# Altium schematic sheet JacdacSingleRgbLed 42.SchDoc
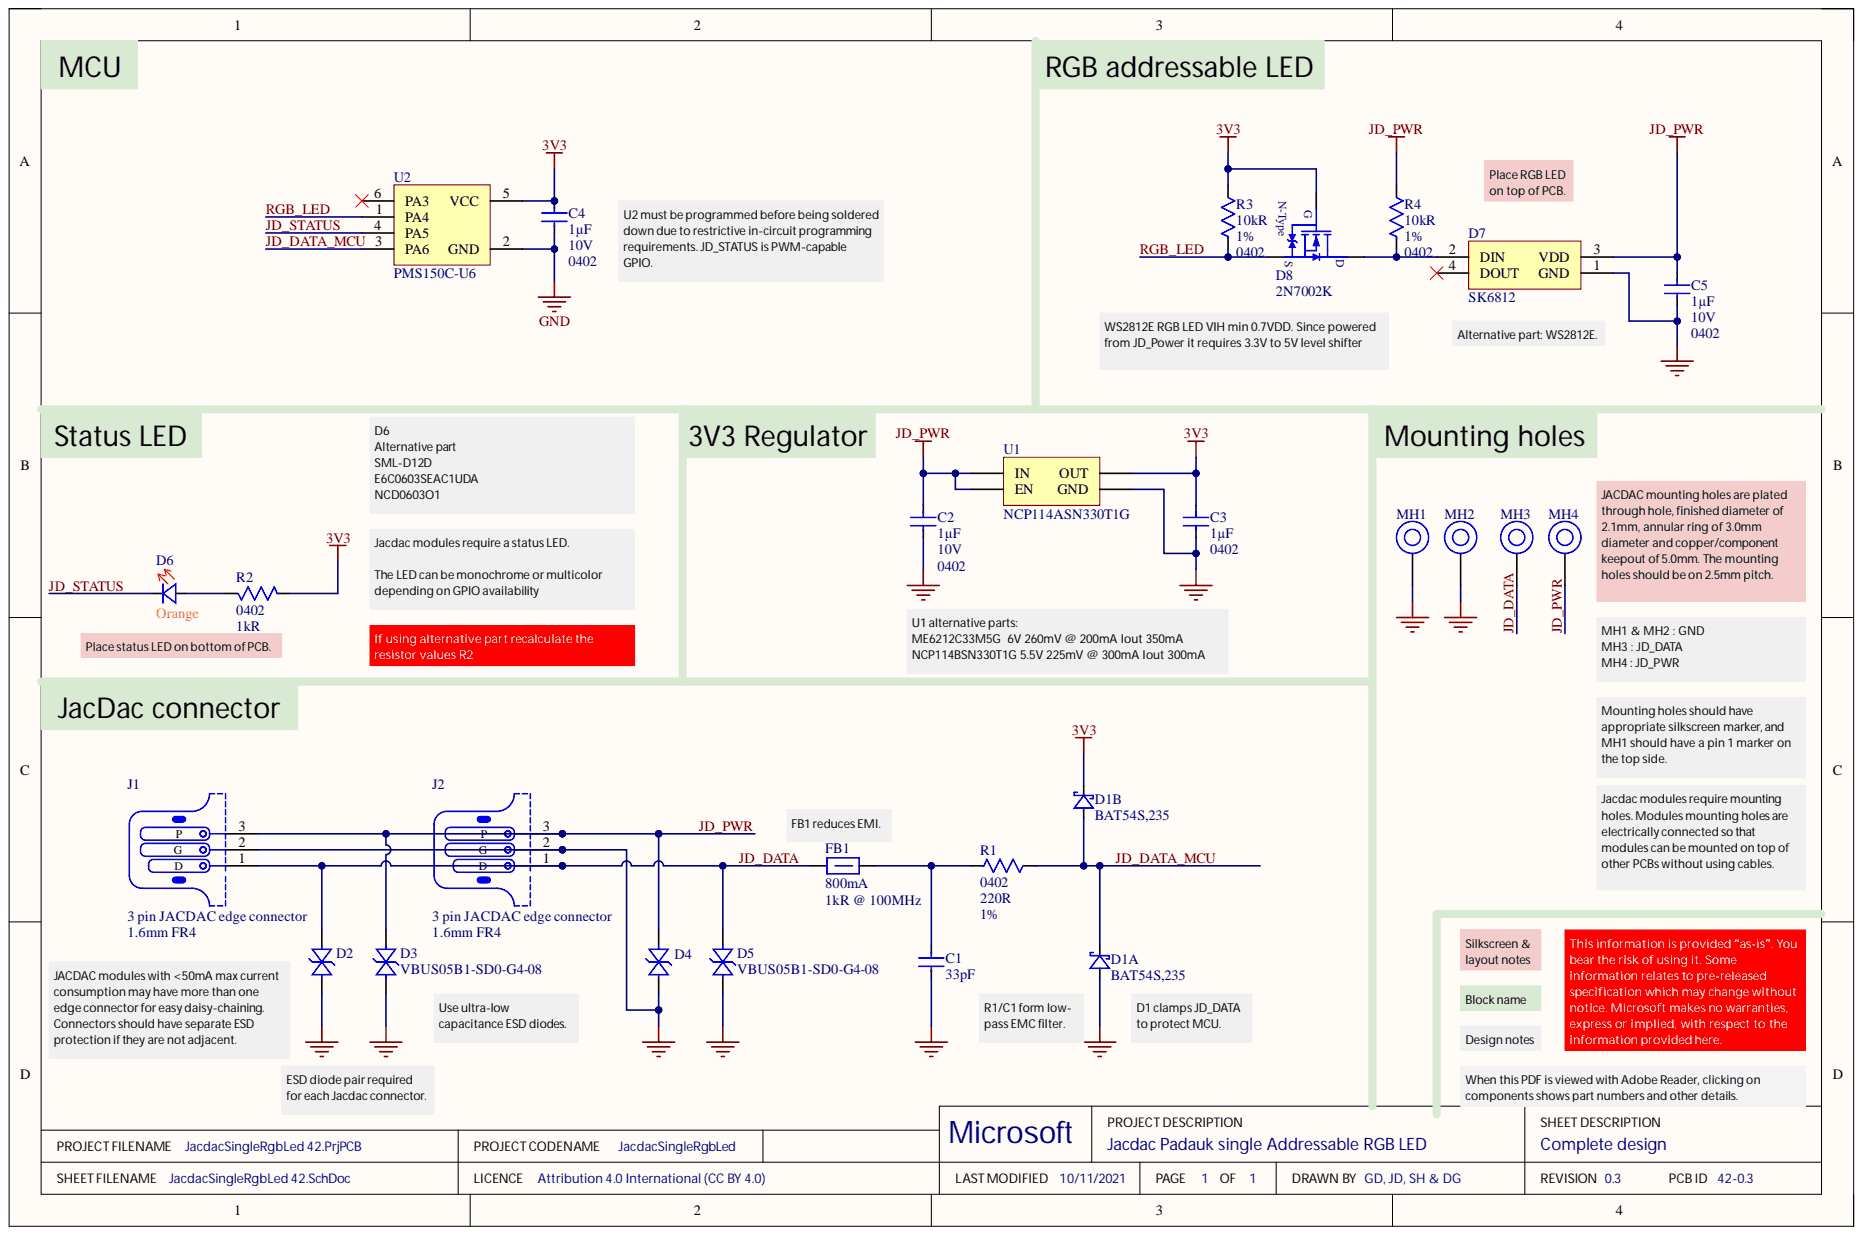
[diagram p0: JacdacSingleRgbLed 42.SchDoc]

## Parts
C1  Capacitance: 33pF
C2  Capacitance: 1µF  Case Code (Imperial): 0402  Voltage Rating: 10V
C3  Capacitance: 1µF  Case Code (Imperial): 0402
C4  Capacitance: 1µF  Case Code (Imperial): 0402  Voltage Rating: 10V
C5  Capacitance: 1µF  Case Code (Imperial): 0402  Voltage Rating: 10V
D1  Manufacturer Part Number: BAT54S,235  (parts A,B)
D2  (no value)
D3  Manufacturer Part Number: VBUS05B1-SD0-G4-08
D4  (no value)
D5  Manufacturer Part Number: VBUS05B1-SD0-G4-08
D6  Colour: Orange
D7  Manufacturer Part Number: SK6812
D8  Manufacturer Part Number: 2N7002K
FB1  Current Rating: 800mA  Impedance: 1kR @ 100MHz
J1  PCB Type: 1.6mm FR4
J2  PCB Type: 1.6mm FR4
MH1  (no value)
MH2  (no value)
MH3  (no value)
MH4  (no value)
R1  Case Code (Imperial): 0402  Resistance: 220R
R2  Case Code (Imperial): 0402  Resistance: 1kR
R3  Case Code (Imperial): 0402  Resistance: 10kR  Tolerance: 1%
R4  Case Code (Imperial): 0402  Resistance: 10kR  Tolerance: 1%
U1  Manufacturer Part Number: NCP114ASN330T1G
U2  Manufacturer Part Number: PMS150C-U6

## Nets
3V3: C3.2 C4.2 D1.2 D8.1 R2.2 R3.1 U1.5(OUT) U2.5(VCC)
GND: C1.1 C2.1 C3.1 C4.1 C5.1 D1.1 D2.2 D3.2 D4.2 D5.2 D7.1(GND) J1.2 J2.2 MH1.1 MH2.1 U1.2(GND) U2.2(GND)
JD_DATA: D2.1 D5.1 FB1.1 J1.1 J2.1 MH3.1
JD_DATA_MCU: D1.3 R1.1 U2.3(PA6)
JD_PWR: C2.2 C5.2 D3.1 D4.1 D7.3(VDD) J1.3 J2.3 MH4.1 R4.1 U1.1(IN) U1.3(EN)
JD_STATUS: D6.1 U2.4(PA5)
RGB_LED: D8.2 R3.2 U2.1(PA4)
NetC1_2: C1.2 FB1.2 R1.2
NetD6_2: D6.2 R2.1
NetD7_2: D7.2(DIN) D8.3 R4.2

Not connected: D7 4(DOUT); U2 6(PA3)

## Library data of the parts
C1 = (no symbol name): CAP CER 33PF 50V NP0 0402; Manufacturer: Samsung; Manufacturer Part Number: CL05C330JB51PNC; Tolerance: 5%; Case Code (Imperial): 0402; Case Code (Metric): 1005; Case/Package: 0402; Category: Capacitors; ComponentLink1Description: Samsung datasheet online; ComponentLink1URL: http://www.samsungsem.com/kr/support/library/product-catalog/mlcc.jsp; Max Operating Temperature: 125°C; Min Operating Temperature: -55°C; Ratings: AEC-Q200; Supplier 1: Digi-Key; Supplier 2: Mouser; Supplier 3: Future Electronics; Supplier Part Number 1: 1276-6515-1-ND; Supplier Part Number 2: 187-CL05C330JB51PNC; Supplier Part Number 3: 6022520; Voltage Rating: 50V
C2-C5 = (no symbol name): CAP CER 1UF 10V X5R 0402; Manufacturer: Samsung; Manufacturer Part Number: CL05A105KP5NNNC; Material: Ceramic; Tolerance: 10%; Case Code (Metric): 1005; Case/Package: 0402; Category: Capacitors; ComponentLink1Description: Online datasheet; ComponentLink1URL: https://datasheet.ciiva.com/15195/getdatasheetpartid-358390-15195630.pdf; Contact Plating: Tin; Dielectric: X5R; Max Operating Temperature: 85°C; Min Operating Temperature: -55°C; Supplier 1: Digi-Key; Supplier 2: Mouser; Supplier 3: Farnell; Supplier Part Number 1: 1276-1076-1-ND; Supplier Part Number 2: 187-CL05A105KP5NNNC; Supplier Part Number 3: 3013329
  C3: Voltage Rating: 10V
D1 = (no symbol name): DIODE ARRAY SCHOTTKY 30V SOT23; Manufacturer: Nexperia; Category: Rectifier Diodes; ComponentLink1Description: NXP datasheet online; ComponentLink1URL: https://assets.nexperia.com/documents/data-sheet/BAT54_SER.pdf; Supplier 1: Digi-Key; Supplier 2: Mouser; Supplier 3: RSComponents; Supplier Part Number 1: 1727-1868-1-ND; Supplier Part Number 2: 771-BAT54S235; Supplier Part Number 3: 1707990
D2 D4 = (no symbol name): TVS DIODE 5.5V 18V CLP0603; Manufacturer: Vishay Semiconductors; Manufacturer Part Number: VBUS05B1-SD0-G4-08; Capacitance: 0.4pF; Direction: Bidirectional; Element Configuration: Single; Min Breakdown Voltage: 6V; Number of Bidirectional Channels: 1; Peak Pulse Current: 2.5A; Peak Pulse Power: 45W; Power Line Protection: No; Case Code (Imperial): 0201; Case Code (Metric): 0603; Case/Package: 0201; Category: TVS Diodes; Clamping Voltage: 18V; ComponentLink2Description: Online datasheet; ComponentLink2URL: http://www.vishay.com/docs/85899/vbus05b1-sd0.pdf; Max Operating Temperature: 150°C; Min Operating Temperature: -55°C; Number of Pins: 2; Resistor Type: Zener; Reverse Standoff Voltage: 5.5V; Supplier 1: Digi-Key; Supplier 2: Farnell; Supplier 3: Mouser; Supplier 4: Arrow Electronics; Supplier Part Number 1: VBUS05B1-SD0-G4-08GICT-ND; Supplier Part Number 2: 2932858; Supplier Part Number 3: 78-VBUS05B1SD0-G4-08; Supplier Part Number 4: VBUS05B1-SD0-G4-08; Working Voltage: 5.5V
D3 D5 = (no symbol name): TVS DIODE 5.5V 18V CLP0603; Manufacturer: Vishay Semiconductors; Capacitance: 0.4pF; Direction: Bidirectional; Element Configuration: Single; Min Breakdown Voltage: 6V; Number of Bidirectional Channels: 1; Peak Pulse Current: 2.5A; Peak Pulse Power: 45W; Power Line Protection: No; Case Code (Imperial): 0201; Case Code (Metric): 0603; Case/Package: 0201; Category: TVS Diodes; Clamping Voltage: 18V; ComponentLink2Description: Online datasheet; ComponentLink2URL: http://www.vishay.com/docs/85899/vbus05b1-sd0.pdf; Max Operating Temperature: 150°C; Min Operating Temperature: -55°C; Number of Pins: 2; Resistor Type: Zener; Reverse Standoff Voltage: 5.5V; Supplier 1: Digi-Key; Supplier 2: Farnell; Supplier 3: Mouser; Supplier 4: Arrow Electronics; Supplier Part Number 1: VBUS05B1-SD0-G4-08GICT-ND; Supplier Part Number 2: 2932858; Supplier Part Number 3: 78-VBUS05B1SD0-G4-08; Supplier Part Number 4: VBUS05B1-SD0-G4-08; Working Voltage: 5.5V
D6 = (no symbol name): 1.6 x 0.8 x 0.55mm (0603) LED 605nm Orange; Manufacturer: Rohm Semiconductor; Manufacturer Part Number: SML-D12D8WT86; Current - Test: 20mA; Lens Style/Size: Rectangle with Flat Top, 1.2mm x 0.8mm; Lens Type: Diffused, White; Millicandela Rating: 100mcd; Voltage - Forward (Vf) (Typ): 2.2V; Category: Optoelectronics; ComponentLink1Description: http://rohmfs.rohm.com/en/products/databook/datasheet/opto/led/chip_mono/sml-d1.pdf; ComponentLink1URL: http://rohmfs.rohm.com/en/products/databook/datasheet/opto/led/chip_mono/sml-d1.pdf; Luminous Flux @ Current - Test: -; Package / Case: 0603 (1608 Metric); Size / Dimension: 1.60mm L x 0.80mm W; Supplier 1: Digi-Key; Supplier Part Number 1: 511-1577-1-ND; Viewing Angle: -; Wavelength - Dominant: 605nm; Wavelength - Peak: -
D7 = (no symbol name): WS2812B 5050 RGB LED WITH INTEGRATED DRIVER; Manufacturer: Shenzhen LED Color; Color: Blue,Green,Red; Number of LEDs: 10; Category: LEDs; ComponentLink1Description: Online datasheet; ComponentLink1URL: http://www.mouser.com/ds/2/737/SK6812_LED_datasheet_-953546.pdf; Supplier 1: Digi-Key; Supplier 2: Mouser; Supplier 3: Adafruit Industries; Supplier Part Number 1: 1528-1104-ND; Supplier Part Number 2: 485-1655; Supplier Part Number 3: 1655
D8 = (no symbol name): MOSFET Transistor, N Channel, 300 mA, 60 V, 2 ohm, 10 V, 2.5 V; Manufacturer: ON Semiconductor / Fairchild; Continuous Drain Current (ID): 300mA; Drain to Source Breakdown Voltage: 60V; Drain to Source Resistance: 2R; Element Configuration: Single; Gate to Source Voltage (Vgs): 20V; Input Capacitance: 50pF; Max Power Dissipation: 350mW; Number of Elements: 1; Polarity: N-CHANNEL; Threshold Voltage: 2.5V; Turn-Off Delay Time: 30ns; Turn-On Delay Time: 5ns; Case/Package: SOT-23; Category: MOSFETs; ComponentLink1Description: Online datasheet; ComponentLink1URL: https://www.onsemi.com/pdf/datasheet/2n7002k-d.pdf; Contact Plating: Tin,Matte; Drain to Source Voltage (Vdss): 60V; Drain-source On Resistance-Max: 2R; Max Operating Temperature: 150°C; Min Operating Temperature: -55°C; Number of Pins: 3; On-State Resistance: 2R; Power Dissipation: 350mW; Rds On Max: 2R; Supplier 1: Digi-Key; Supplier 2: Mouser; Supplier 3: Farnell; Supplier Part Number 1: 2N7002KCT-ND; Supplier Part Number 2: 512-2N7002K; Supplier Part Number 3: 2453382; Voltage: 60V
FB1 = (no symbol name): 0603 1k@100MHz 0.8A chip; Manufacturer: TDK; Manufacturer Part Number: MPZ1608S102ATA00; Core Material: Ferrite; DC Resistance (DCR): 300mR; Tolerance: 25%; Case Code (Imperial): 0603; Case Code (Metric): 1608; Case/Package: 0603; ComponentLink2Description: datasheet online; ComponentLink2URL: https://www.mouser.co.uk/datasheet/2/400/eads_commercial_power_mpz1608_en-923650.pdf; ComponentLink3Description: datasheet; Max DC Current: 800mA; Supplier 1: Digi-Key; Supplier 2: Mouser; Supplier Part Number 1: 445-8694-1-ND; Supplier Part Number 2: 810-MPZ1608S102ATA00; ComponentLink3URL: Y:\System\Altium\Libraries 2014\TDK\Datasheets\eads_commercial_power_mpz1608_en-923650.pdf
J1 J2 = (no symbol name): 3 pin JACDAC edge connector 1.27mm pitch; Manufacturer Part Number: JACDAC_EDGE_CONNECTOR; ComponentLink1Description: Yuliang JACDAC cable connector drawing online; ComponentLink1URL: https://github.com/microsoft/jacdac-mdk/blob/main/connector/JACDAC-Connector-by-Yuliang-2020-11-28.pdf; ComponentLink2Description: JACDAC PCB edge connector spec onlin; ComponentLink2URL: https://github.com/microsoft/jacdac-mdk/blob/main/connector/JACDAC_PCB_Edge_Connector_Drawing_JD-PEC-01_rev_01.pdf
MH1-MH4 = (no symbol name): Mounting Hole 5.0mm keepout, 3.0mm annualar ring and 2.1mm Drill PTH
R1 = (no symbol name): RES SMD 220 OHM 1% 1/16W 0402; Manufacturer: Yageo; Manufacturer Part Number: RC0402FR-07220RL; Max Power Dissipation: 63mW; Power Rating: 62.5mW; Temperature Coefficient: 100ppm/°C; Voltage Rating: 50V; Case Code (Metric): 1005; Case/Package: 0402; Category: Resistors; ComponentLink1Description: Online datasheet; ComponentLink1URL: https://datasheet.ciiva.com/11819/0900766b80c66297-11819466.pdf; Features: Moisture Resistant; Max Operating Temperature: 155°C; Min Operating Temperature: -55°C; Number of Pins: 2; Number of Terminations: 2; Resistor Type: Thick Film; Supplier 1: Digi-Key; Supplier 2: Mouser; Supplier 3: Farnell; Supplier Part Number 1: 311-220LRCT-ND; Supplier Part Number 2: 603-RC0402FR-07220RL; Supplier Part Number 3: 2147864; Termination: SMD/SMT; Tolerance: 1%; Voltage Rating (DC): 50V
R2 = (no symbol name): RES SMD 1K OHM 1% 1/16W 0402; Manufacturer: Yageo; Manufacturer Part Number: RC0402FR-071KL; Power Rating: 62.5mW; Temperature Coefficient: 100ppm/°C; Voltage Rating: 50V; Case Code (Metric): 1005; Case/Package: 0402; Category: Resistors; ComponentLink2Description: Online datasheet; ComponentLink2URL: https://datasheet.ciiva.com/12666/pyu-rc0402-51-rohs-l-6-r-12666741.pdf; Max Operating Temperature: 155°C; Min Operating Temperature: -55°C; Number of Pins: 2; Resistor Type: Thick Film; Supplier 1: Digi-Key; Supplier 2: Mouser; Supplier 3: Farnell; Supplier Part Number 1: 311-1.00KLRDKR-ND; Supplier Part Number 2: 603-RC0402FR-071KL; Supplier Part Number 3: 2132441; Termination: SMD/SMT; Tolerance: 1%; Voltage Rating (DC): 50V
R3 R4 = (no symbol name): RES SMD 10K OHM 1% 1/16W 0402; Manufacturer: Yageo; Manufacturer Part Number: RC0402FR-0710KL; Power Rating: 62.5mW; Temperature Coefficient: 100ppm/°C; Voltage Rating: 50V; Case Code (Metric): 1005; Case/Package: 0402; Category: Resistors; ComponentLink3Description: Online datasheet; ComponentLink3URL: https://datasheet.ciiva.com/7849/1686128-7849814.pdf; Lead Pitch: 0.2mm; Max Operating Temperature: 155°C; Min Operating Temperature: -55°C; Number of Pins: 2; Number of Terminations: 2; Resistor Type: Thick Film; Supplier 1: Digi-Key; Supplier 2: Mouser; Supplier 3: Farnell; Supplier Part Number 1: 311-10.0KLRCT-ND; Supplier Part Number 2: 603-RC0402FR-0710KL; Supplier Part Number 3: 2363463; Termination: SMD/SMT; Voltage Rating (DC): 50V; Working Voltage: 50V
U1 = (no symbol name): ON SEMICONDUCTOR - NCP114ASN330T1G - LDO Voltage Regulator, Fixed, 1.7 V to 5.5 V in, 3.3 V/300 mA out, 150 mV drop, TSOP-5; Dropout Voltage: 225mV; Max Input Voltage: 5.5V; Min Output Voltage: 3.3V; Number of Regulators: 1; Output Configuration: Positive; Output Current: 300mA; Power Supply Rejection Ratio (PSRR): 75dB; Quiescent Current: 95uA; Case/Package: SOT-23-5; Category: Voltage Regulators - Linear; ComponentLink3Description: On Semi datasheet online; ComponentLink3URL: http://www.onsemi.com/pub/Collateral/NCP114-D.PDF; Manufacturer: ON Semiconductor; Max Operating Temperature: 85°C; Min Operating Temperature: -40°C; Output Type: Fixed; Supplier 1: Digi-Key; Supplier 2: RSComponents; Supplier 3: Mouser; Supplier Part Number 1: NCP114ASN330T1GOSCT-ND; Supplier Part Number 2: 1263178; Supplier Part Number 3: 863-NCP114ASN330T1G
U2 = (no symbol name): 8bit OTP Type IO Controller; Manufacturer: PADAUK; ComponentLink1Description: Padauk datasheet online; ComponentLink1URL: http://www.padauk.com.tw/upload/doc/PMS15A,PMS150C%20datasheet%20V108_EN_20200724.pdf; Package: SOT-23-6; Supplier 1: LCSC; Supplier Order Qty 1: 3000; Supplier Part Number 1: C168658
